# Altium schematic sheet Sheet1.SchDoc
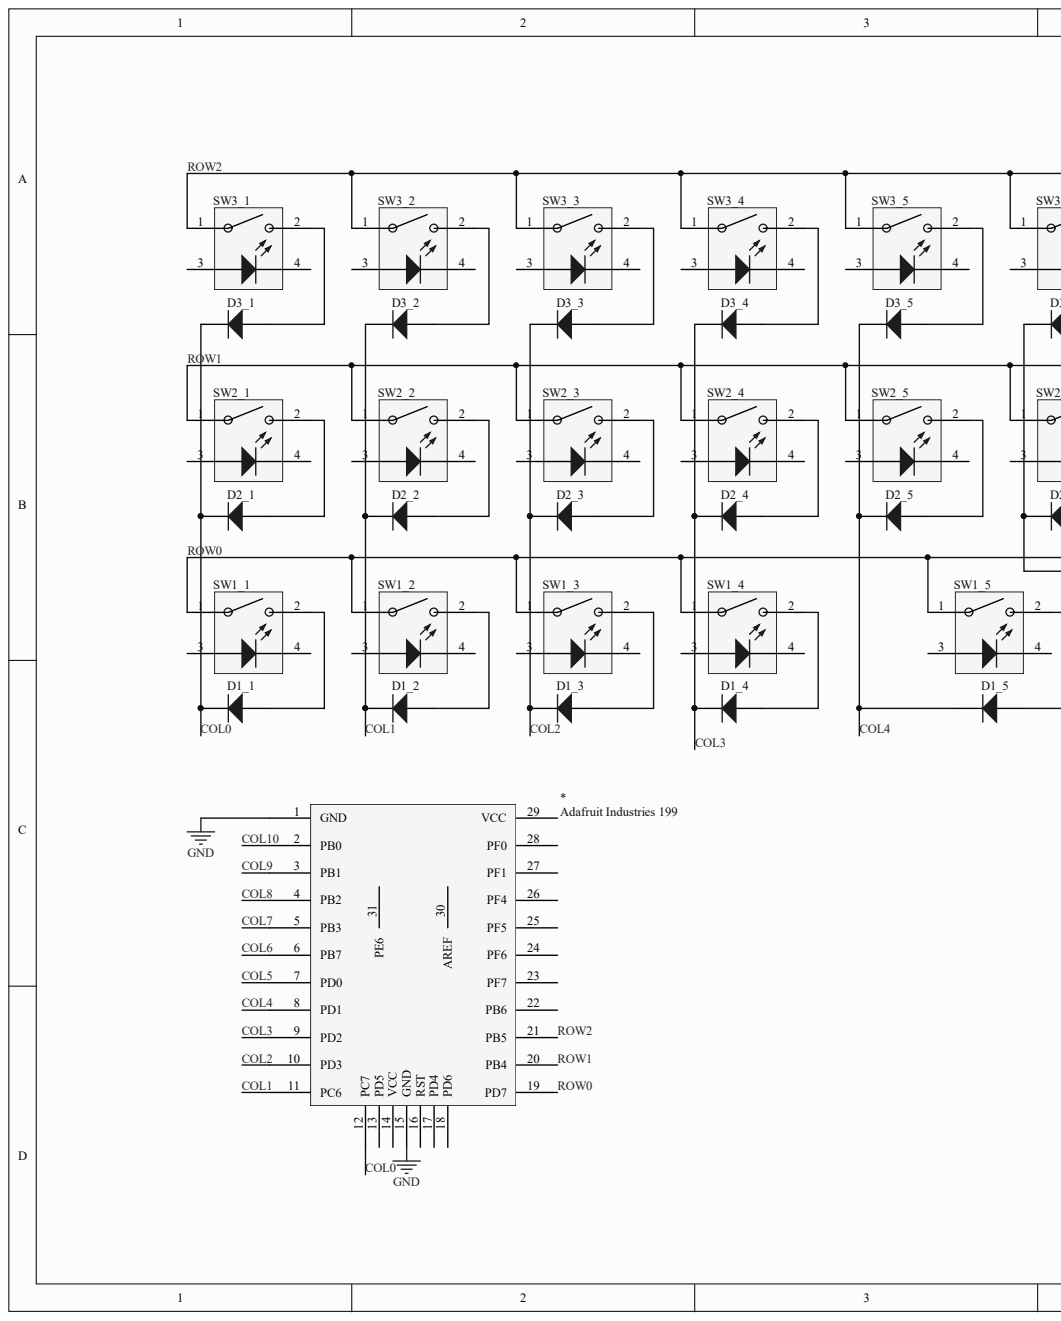
[diagram p0: Sheet1.SchDoc, left]
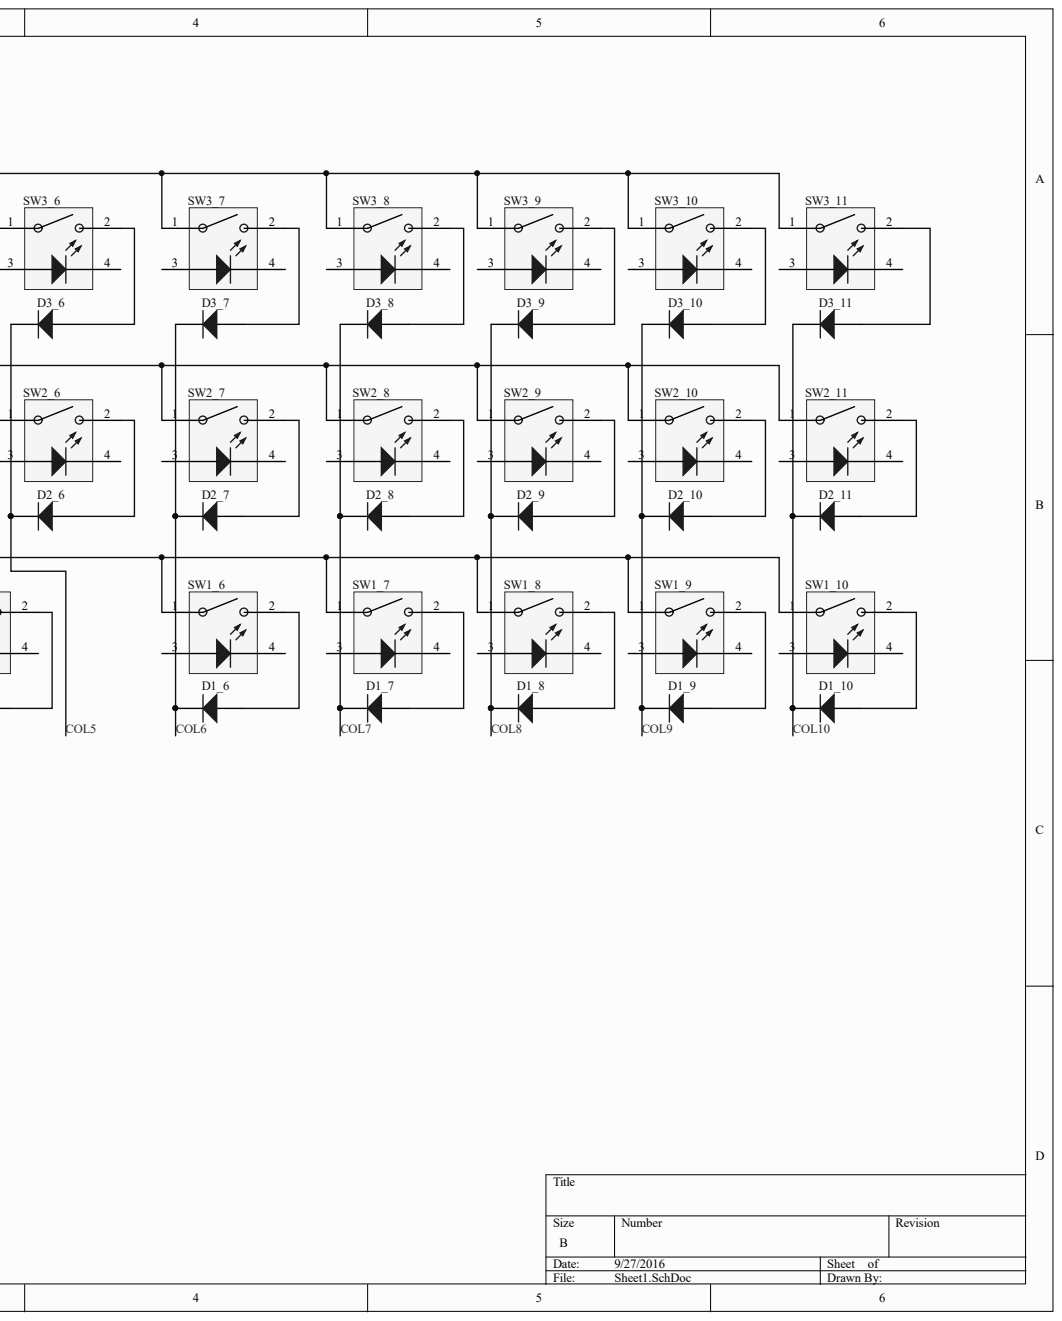
[diagram p1: Sheet1.SchDoc, right]

## Parts
*  Adafruit Industries 199  [PCB-nkfreo9v7te9le7eoxz3-1]
D1_1  Fairchild Semiconductor 1N4148  [PCB-814702-1]  (value not drawn)
D1_2  Fairchild Semiconductor 1N4148  [PCB-814702-1]  (value not drawn)
D1_3  Fairchild Semiconductor 1N4148  [PCB-814702-1]  (value not drawn)
D1_4  Fairchild Semiconductor 1N4148  [PCB-814702-1]  (value not drawn)
D1_5  Fairchild Semiconductor 1N4148  [PCB-814702-1]  (value not drawn)
D1_6  Fairchild Semiconductor 1N4148  [PCB-814702-1]  (value not drawn)
D1_7  Fairchild Semiconductor 1N4148  [PCB-814702-1]  (value not drawn)
D1_8  Fairchild Semiconductor 1N4148  [PCB-814702-1]  (value not drawn)
D1_9  Fairchild Semiconductor 1N4148  [PCB-814702-1]  (value not drawn)
D1_10  Fairchild Semiconductor 1N4148  [PCB-814702-1]  (value not drawn)
D2_1  Fairchild Semiconductor 1N4148  [PCB-814702-1]  (value not drawn)
D2_2  Fairchild Semiconductor 1N4148  [PCB-814702-1]  (value not drawn)
D2_3  Fairchild Semiconductor 1N4148  [PCB-814702-1]  (value not drawn)
D2_4  Fairchild Semiconductor 1N4148  [PCB-814702-1]  (value not drawn)
D2_5  Fairchild Semiconductor 1N4148  [PCB-814702-1]  (value not drawn)
D2_6  Fairchild Semiconductor 1N4148  [PCB-814702-1]  (value not drawn)
D2_7  Fairchild Semiconductor 1N4148  [PCB-814702-1]  (value not drawn)
D2_8  Fairchild Semiconductor 1N4148  [PCB-814702-1]  (value not drawn)
D2_9  Fairchild Semiconductor 1N4148  [PCB-814702-1]  (value not drawn)
D2_10  Fairchild Semiconductor 1N4148  [PCB-814702-1]  (value not drawn)
D2_11  Fairchild Semiconductor 1N4148  [PCB-814702-1]  (value not drawn)
D3_1  Fairchild Semiconductor 1N4148  [PCB-814702-1]  (value not drawn)
D3_2  Fairchild Semiconductor 1N4148  [PCB-814702-1]  (value not drawn)
D3_3  Fairchild Semiconductor 1N4148  [PCB-814702-1]  (value not drawn)
D3_4  Fairchild Semiconductor 1N4148  [PCB-814702-1]  (value not drawn)
D3_5  Fairchild Semiconductor 1N4148  [PCB-814702-1]  (value not drawn)
D3_6  Fairchild Semiconductor 1N4148  [PCB-814702-1]  (value not drawn)
D3_7  Fairchild Semiconductor 1N4148  [PCB-814702-1]  (value not drawn)
D3_8  Fairchild Semiconductor 1N4148  [PCB-814702-1]  (value not drawn)
D3_9  Fairchild Semiconductor 1N4148  [PCB-814702-1]  (value not drawn)
D3_10  Fairchild Semiconductor 1N4148  [PCB-814702-1]  (value not drawn)
D3_11  Fairchild Semiconductor 1N4148  [PCB-814702-1]  (value not drawn)
SW1_1  Molex / Waldom / Cherry MX1A-11NW  [PCB-1275094-1]  (value not drawn)
SW1_2  Molex / Waldom / Cherry MX1A-11NW  [PCB-1275094-1]  (value not drawn)
SW1_3  Molex / Waldom / Cherry MX1A-11NW  [PCB-1275094-1]  (value not drawn)
SW1_4  Molex / Waldom / Cherry MX1A-11NW  [PCB-1275094-1]  (value not drawn)
SW1_5  Molex / Waldom / Cherry MX1A-11NW  [PCB-1275094-1]  (value not drawn)
SW1_6  Molex / Waldom / Cherry MX1A-11NW  [PCB-1275094-1]  (value not drawn)
SW1_7  Molex / Waldom / Cherry MX1A-11NW  [PCB-1275094-1]  (value not drawn)
SW1_8  Molex / Waldom / Cherry MX1A-11NW  [PCB-1275094-1]  (value not drawn)
SW1_9  Molex / Waldom / Cherry MX1A-11NW  [PCB-1275094-1]  (value not drawn)
SW1_10  Molex / Waldom / Cherry MX1A-11NW  [PCB-1275094-1]  (value not drawn)
SW2_1  Molex / Waldom / Cherry MX1A-11NW  [PCB-1275094-1]  (value not drawn)
SW2_2  Molex / Waldom / Cherry MX1A-11NW  [PCB-1275094-1]  (value not drawn)
SW2_3  Molex / Waldom / Cherry MX1A-11NW  [PCB-1275094-1]  (value not drawn)
SW2_4  Molex / Waldom / Cherry MX1A-11NW  [PCB-1275094-1]  (value not drawn)
SW2_5  Molex / Waldom / Cherry MX1A-11NW  [PCB-1275094-1]  (value not drawn)
SW2_6  Molex / Waldom / Cherry MX1A-11NW  [PCB-1275094-1]  (value not drawn)
SW2_7  Molex / Waldom / Cherry MX1A-11NW  [PCB-1275094-1]  (value not drawn)
SW2_8  Molex / Waldom / Cherry MX1A-11NW  [PCB-1275094-1]  (value not drawn)
SW2_9  Molex / Waldom / Cherry MX1A-11NW  [PCB-1275094-1]  (value not drawn)
SW2_10  Molex / Waldom / Cherry MX1A-11NW  [PCB-1275094-1]  (value not drawn)
SW2_11  Molex / Waldom / Cherry MX1A-11NW  [PCB-1275094-1]  (value not drawn)
SW3_1  Molex / Waldom / Cherry MX1A-11NW  [PCB-1275094-1]  (value not drawn)
SW3_2  Molex / Waldom / Cherry MX1A-11NW  [PCB-1275094-1]  (value not drawn)
SW3_3  Molex / Waldom / Cherry MX1A-11NW  [PCB-1275094-1]  (value not drawn)
SW3_4  Molex / Waldom / Cherry MX1A-11NW  [PCB-1275094-1]  (value not drawn)
SW3_5  Molex / Waldom / Cherry MX1A-11NW  [PCB-1275094-1]  (value not drawn)
SW3_6  Molex / Waldom / Cherry MX1A-11NW  [PCB-1275094-1]  (value not drawn)
SW3_7  Molex / Waldom / Cherry MX1A-11NW  [PCB-1275094-1]  (value not drawn)
SW3_8  Molex / Waldom / Cherry MX1A-11NW  [PCB-1275094-1]  (value not drawn)
SW3_9  Molex / Waldom / Cherry MX1A-11NW  [PCB-1275094-1]  (value not drawn)
SW3_10  Molex / Waldom / Cherry MX1A-11NW  [PCB-1275094-1]  (value not drawn)
SW3_11  Molex / Waldom / Cherry MX1A-11NW  [PCB-1275094-1]  (value not drawn)

## Nets
GND: *.1(GND) *.15(GND)
COL0: *.12(PC7) D1_1.2 D2_1.2 D3_1.2
COL1: *.11(PC6) D1_2.2 D2_2.2 D3_2.2
COL2: *.10(PD3) D1_3.2 D2_3.2 D3_3.2
COL3: *.9(PD2) D1_4.2 D2_4.2 D3_4.2
COL4: *.8(PD1) D1_5.2 D2_5.2 D3_5.2
COL5: *.7(PD0) D2_6.2 D3_6.2
COL6: *.6(PB7) D1_6.2 D2_7.2 D3_7.2
COL7: *.5(PB3) D1_7.2 D2_8.2 D3_8.2
COL8: *.4(PB2) D1_8.2 D2_9.2 D3_9.2
COL9: *.3(PB1) D1_9.2 D2_10.2 D3_10.2
COL10: *.2(PB0) D1_10.2 D2_11.2 D3_11.2
ROW0: *.19(PD7) SW1_1.1 SW1_2.1 SW1_3.1 SW1_4.1 SW1_5.1 SW1_6.1 SW1_7.1 SW1_8.1 SW1_9.1 SW1_10.1
ROW1: *.20(PB4) SW2_1.1 SW2_2.1 SW2_3.1 SW2_4.1 SW2_5.1 SW2_6.1 SW2_7.1 SW2_8.1 SW2_9.1 SW2_10.1 SW2_11.1
ROW2: *.21(PB5) SW3_1.1 SW3_2.1 SW3_3.1 SW3_4.1 SW3_5.1 SW3_6.1 SW3_7.1 SW3_8.1 SW3_9.1 SW3_10.1 SW3_11.1
NetD1_1_1: D1_1.1 SW1_1.2
NetD1_2_1: D1_2.1 SW1_2.2
NetD1_3_1: D1_3.1 SW1_3.2
NetD1_4_1: D1_4.1 SW1_4.2
NetD1_5_1: D1_5.1 SW1_5.2
NetD1_6_1: D1_6.1 SW1_6.2
NetD1_7_1: D1_7.1 SW1_7.2
NetD1_8_1: D1_8.1 SW1_8.2
NetD1_9_1: D1_9.1 SW1_9.2
NetD1_10_1: D1_10.1 SW1_10.2
NetD2_1_1: D2_1.1 SW2_1.2
NetD2_2_1: D2_2.1 SW2_2.2
NetD2_3_1: D2_3.1 SW2_3.2
NetD2_4_1: D2_4.1 SW2_4.2
NetD2_5_1: D2_5.1 SW2_5.2
NetD2_6_1: D2_6.1 SW2_6.2
NetD2_7_1: D2_7.1 SW2_7.2
NetD2_8_1: D2_8.1 SW2_8.2
NetD2_9_1: D2_9.1 SW2_9.2
NetD2_10_1: D2_10.1 SW2_10.2
NetD2_11_1: D2_11.1 SW2_11.2
NetD3_1_1: D3_1.1 SW3_1.2
NetD3_2_1: D3_2.1 SW3_2.2
NetD3_3_1: D3_3.1 SW3_3.2
NetD3_4_1: D3_4.1 SW3_4.2
NetD3_5_1: D3_5.1 SW3_5.2
NetD3_6_1: D3_6.1 SW3_6.2
NetD3_7_1: D3_7.1 SW3_7.2
NetD3_8_1: D3_8.1 SW3_8.2
NetD3_9_1: D3_9.1 SW3_9.2
NetD3_10_1: D3_10.1 SW3_10.2
NetD3_11_1: D3_11.1 SW3_11.2

Not connected: * 13(PD5) 14(VCC) 16(RST) 17(PD4) 18(PD6) 22(PB6) 23(PF7) 24(PF6) 25(PF5) 26(PF4) 27(PF1) 28(PF0) 29(VCC) 30(AREF) 31(PE6); SW1_1 3 4; SW1_2 3 4; SW1_3 3 4; SW1_4 3 4; SW1_5 3 4; SW1_6 3 4; SW1_7 3 4; SW1_8 3 4; SW1_9 3 4; SW1_10 3 4; SW2_1 3 4; SW2_2 3 4; SW2_3 3 4; SW2_4 3 4; SW2_5 3 4; SW2_6 3 4; SW2_7 3 4; SW2_8 3 4; SW2_9 3 4; SW2_10 3 4; SW2_11 3 4; SW3_1 3 4; SW3_2 3 4; SW3_3 3 4; SW3_4 3 4; SW3_5 3 4; SW3_6 3 4; SW3_7 3 4; SW3_8 3 4; SW3_9 3 4; SW3_10 3 4; SW3_11 3 4

## Library data of the parts
* = CMP-fe60369ded1c21a7-1: DREMEL 199 Cutter, High Speed, 3/8. More details: High Speed Cutter, Maximum Cutting Diameter 3/8 Inch, 1/8 Inch Shan...; Manufacturer Part Number: 199; Component Kind: Standard; Library Name: FavoritesLibrary; OctopartID: fe60369ded1c21a7; Octopart URL: http://octopart.com/199-adafruit+industries-32978458
D1_1-D1_10 D2_1-D2_11 D3_1-D3_11 = CMP-814702-2: DIODE GEN PURP 100V 200MA DO35; Capacitance: 4e-12 F; Current Rating: 0.2 A; Forward Voltage: 1.0 V; Lead-Free Status: Lead Free; Polarity: Standard; Voltage Rating (DC): 100.0 V; Case/Package: DO-35; Component Kind: Standard; Library Name: CommunityVault; Lifecycle Status: Active; Mounting Style: Through Hole; Number of Pins: 2; OctopartID: ae03670d61fd71c4; Octopart Url: https://octopart.com/1n4148-fairchild+semiconductor-322200; Packaging: Bulk; REACH SVHC Compliance: No SVHC; RoHS: Compliant
SW1_1-SW1_10 SW2_1-SW2_11 SW3_1-SW3_11 = CMP-1275094-5: SWITCH PUSH SPST-NO 0.01A 12V; Lead-Free Status: Lead Free; Component Kind: Standard; Contact Current Rating: 0.01 A; Contact Plating: Gold; Contacts Type: SPST-NO; Library Name: CommunityVault; OctopartID: ac196513e8be73de; Octopart Url: https://octopart.com/mx1a-11nw-cherry-25025; Orientation: Vertical; Packaging: Bulk; REACH SVHC Compliance: No SVHC; RoHS: Compliant; Size-Length: 0.0156 m; Size-Width: 0.0156 m
